# Altium schematic sheet schematic.SchDoc
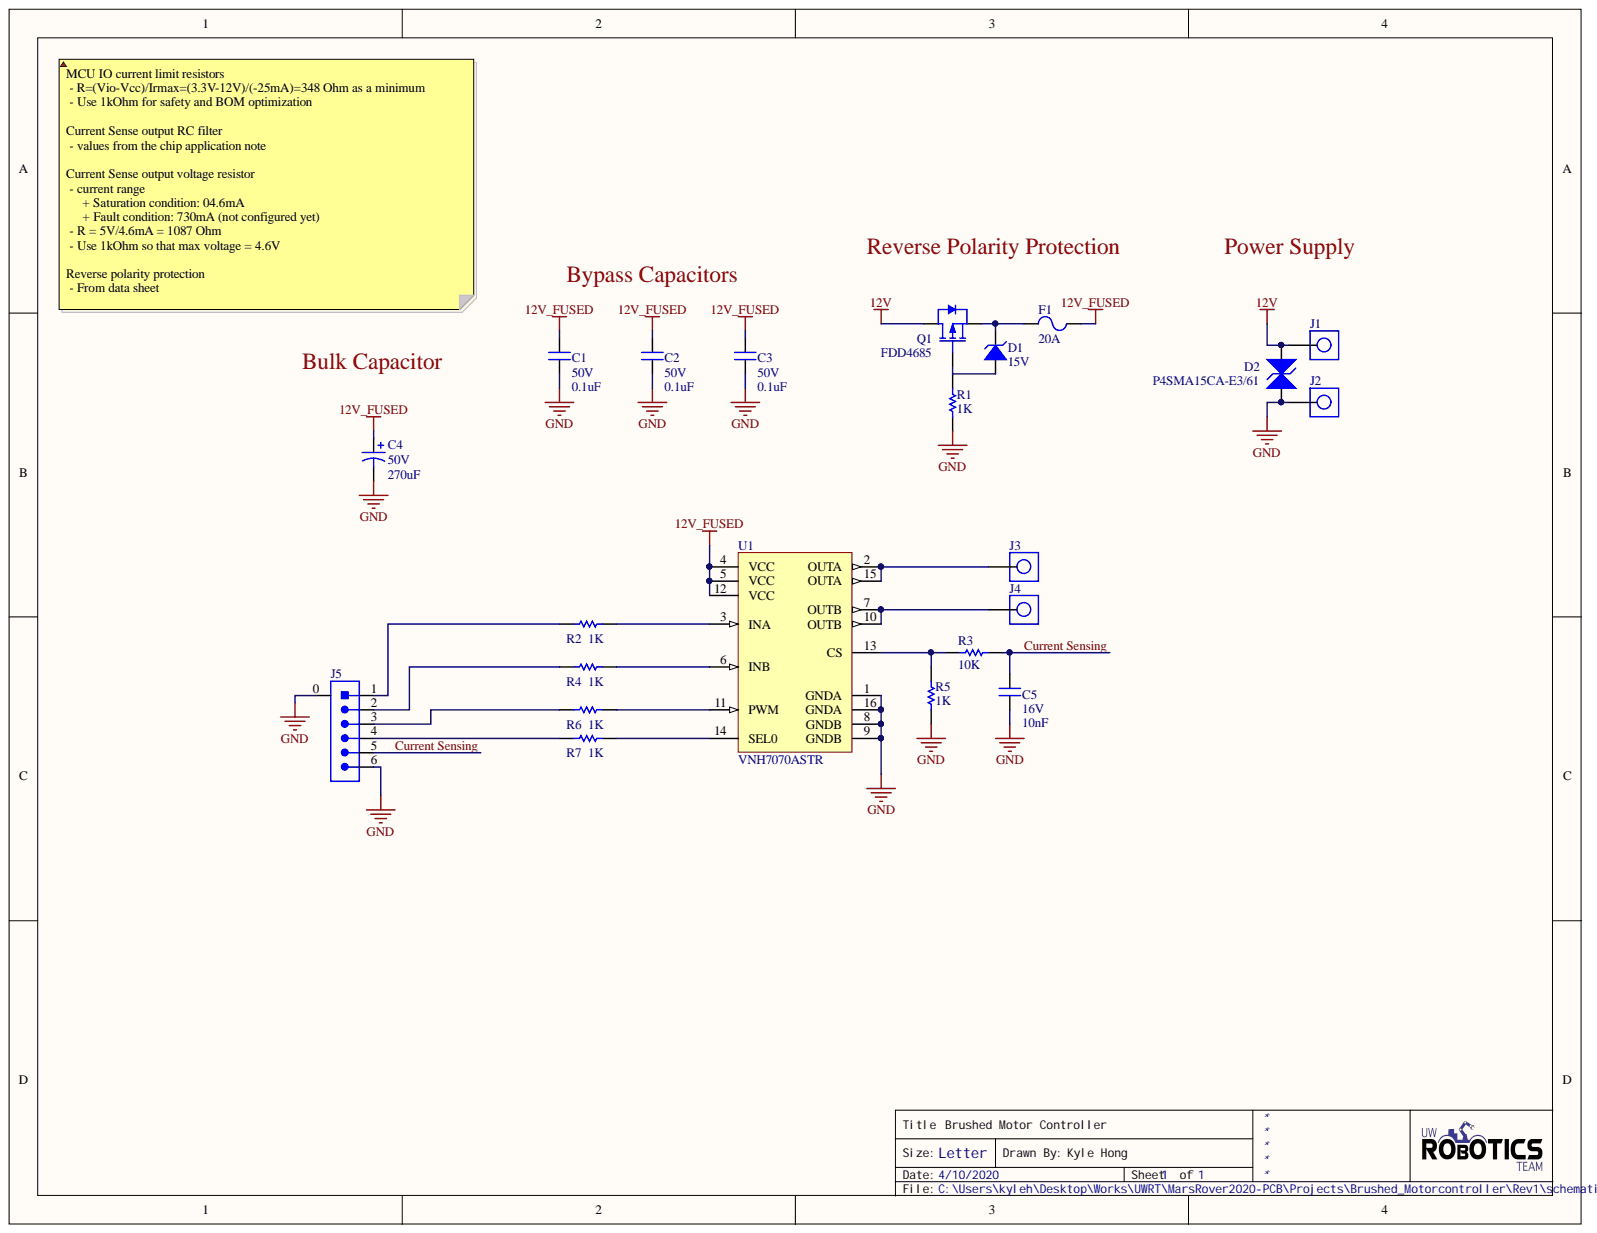
[diagram p0: schematic.SchDoc]

## Parts
C1  value 0.1uF  Voltage Rating: 50V  [CAPC0603(1608)55_N]  (hidden comment: CAP_0.1uF_50V_0603)
C2  value 0.1uF  Voltage Rating: 50V  [CAPC0603(1608)55_N]  (hidden comment: CAP_0.1uF_50V_0603)
C3  value 0.1uF  Voltage Rating: 50V  [CAPC0603(1608)55_N]  (hidden comment: CAP_0.1uF_50V_0603)
C4  Capacitance: 270uF  Voltage Rating: 50V  [EEE-FK1H271SP]  (hidden comment: CAP_270uF_50V_ALUM)
C5  value 10nF  Voltage Rating: 16V  [CAPC0603(1608)55_N]  (hidden comment: CAP_10nF_0603)
D1  Voltage Rating (DC): 15V  [SOD-323]  (hidden comment: DIO_ZENER_15V)
D2  Manufacturer Part Number 1: P4SMA15CA-E3/61  [TVS_DIODE_BIDIR_DO-214AC_SMA]  (hidden comment: TVS_DIODE_BIDIR_12.8V)
F1  Current Rating: 20A  [FUSE_1206]  (hidden comment: FUSE_20A_1206)
J1  Screw Terminal 6-32  [7701_KEYSTONE]  (value not drawn)
J2  Screw Terminal 6-32  [7701_KEYSTONE]  (value not drawn)
J3  Screw Terminal 6-32  [7701_KEYSTONE]  (value not drawn)
J4  Screw Terminal 6-32  [7701_KEYSTONE]  (value not drawn)
J5  CON_6M_SM_RA  [MOLEX-5024940670]  (value not drawn)
Q1  Manufacturer Part Number 1: FDD4685  [D-PAK]  (hidden comment: PMOS_40V_8.4A)
R1  value 1K  [RESC0603(1608)_N]  (hidden comment: RES_1K_0603)
R2  value 1K  [RESC0603(1608)_N]  (hidden comment: RES_1K_0603)
R3  value 10K  [RESC0603(1608)_N]  (hidden comment: RES_10K_0603)
R4  value 1K  [RESC0603(1608)_N]  (hidden comment: RES_1K_0603)
R5  value 1K  [RESC0603(1608)_N]  (hidden comment: RES_1K_0603)
R6  value 1K  [RESC0603(1608)_N]  (hidden comment: RES_1K_0603)
R7  value 1K  [RESC0603(1608)_N]  (hidden comment: RES_1K_0603)
U1  Interface: PWM  Manufacturer Part Number 1: VNH7070ASTR  [SO-16N]  (hidden comment: IC_MOTOR_DRIVER_ST)

## Nets
12V: D2.1 J1.1 Q1.1
12V_FUSED: C1.2 C2.2 C3.1 C4.1 F1.2 U1.4(VCC) U1.5(VCC) U1.12(VCC)
GND: C1.1 C2.1 C3.2 C4.2 C5.1 D2.2 J2.1 J5.0 J5.6 R5.1 U1.1(GNDA) U1.8(GNDB) U1.9(GNDB) U1.16(GNDA)
Current Sensing: C5.2 J5.5 R3.2
NetD1_1: D1.1 Q1.2
NetD1_2: D1.2 F1.1 Q1.3
NetJ3_1: J3.1 U1.2(OUTA) U1.15(OUTA)
NetJ4_1: J4.1 U1.7(OUTB) U1.10(OUTB)
NetJ5_1: J5.1 R2.1
NetJ5_2: J5.2 R4.1
NetJ5_3: J5.3 R6.1
NetJ5_4: J5.4 R7.1
NetR2_2: R2.2 U1.3(INA)
NetR3_1: R3.1 R5.2 U1.13(CS)
NetR4_2: R4.2 U1.6(INB)
NetR6_2: R6.2 U1.11(PWM)
NetR7_2: R7.2 U1.14(SEL0)

Not connected: R1 1 2

## Library data of the parts
C1-C3 = CAP_0.1uF_50V_0603: CAP CER 0.1UF 50V X7R 0603; Manufacturer 1: Yageo; Manufacturer Part Number 1: CC0603KRX7R9BB104; Component Kind: Standard; Dimensions: 0.063" L x 0.031" W (1.60mm x 0.80mm); Mount: Surface Mount; Package: 0603 (1608 Metric); Signal Integrity: Cap; Simulation: CAP; Supplier 1: Digi-Key; Supplier Part Number 1: 311-1344-1-ND; Temperature Coefficient: X7R; Library Name: UWRT_Schematic_Library_2020.SchLib
C4 = CAP_270uF_50V_ALUM: CAP ALUM 270UF 20% 50V RADIAL; Manufacturer 1: Panasonic; Manufacturer Part Number 1: EEEFK1H271SP; ESR (Equivalent Series Resistance): 180mR; Life (Hours): 2000Hour; Polarity: Polar; Ripple Current: 435.5mA; Ripple Current (AC): 670mA; Case/Package: Radial; Component Kind: Standard; ComponentLink1Description: https://media.digikey.com/pdf/Data%20Sheets/Panasonic%20Capacitors%20PDFs/FKS_Series_Type_V.pdf?src-supplier=Digi-Key; ComponentLink1URL: https://media.digikey.com/pdf/Data%20Sheets/Panasonic%20Capacitors%20PDFs/FKS_Series_Type_V.pdf?src-supplier=Digi-Key; ComponentLink2Description: https://media.digikey.com/pdf/Data%20Sheets/Panasonic%20Capacitors%20PDFs/FKS-V_Series_NPI.pdf?src-supplier=Digi-Key; ComponentLink2URL: https://media.digikey.com/pdf/Data%20Sheets/Panasonic%20Capacitors%20PDFs/FKS-V_Series_NPI.pdf?src-supplier=Digi-Key; ComponentLink3Description: http://www.farnell.com/datasheets/2813662.pdf?src-supplier=Farnell; ComponentLink3URL: http://www.farnell.com/datasheets/2813662.pdf?src-supplier=Farnell; ComponentLink4Description: http://www.farnell.com/datasheets/1996420.pdf?src-supplier=Element14; ComponentLink4URL: http://www.farnell.com/datasheets/1996420.pdf?src-supplier=Element14; ComponentLink5Description: https://industrial.panasonic.com/cdbs/www-data/pdf/RDE0000/DME0000COL90.pdf?src-supplier=Digi-Key; ComponentLink5URL: https://industrial.panasonic.com/cdbs/www-data/pdf/RDE0000/DME0000COL90.pdf?src-supplier=Digi-Key; Diameter: 10mm; Height: 10.2mm; Height - Seated (Max): 0.413inch; Max Operating Temperature: 105°C; Min Operating Temperature: -55°C; Mount: Surface Mount; Package Quantity: 500; Packaging: Cut Tape; Pricing 1: 1=0.98000, 10=0.74800, 100=0.53500 (USD); REACH SVHC: Unknown; RoHS: Yes; Stock 1: 730; Supplier 1: Digi-Key; Supplier Part Number 1: P18967CT-ND; Tolerance: 20%; Voltage Rating (DC): 50V; Library Name: UWRT_Schematic_Library_2020.SchLib
C5 = CAP_10nF_0603: CAP CER 10000PF 16V X7R 0603; Manufacturer 1: Yageo; Manufacturer Part Number 1: CC0603KRX7R7BB103; Component Kind: Standard; Dimensions: 0.063" L x 0.031" W (1.60mm x 0.80mm); Mount: Surface Mount; Package: 0603 (1608 Metric); Signal Integrity: Cap; Simulation: CAP; Supplier 1: Digi-Key; Supplier Part Number 1: 311-3369-1-ND; Temperature Coefficient: X7R; Library Name: UWRT_Schematic_Library_2020.SchLib
D1 = DIO_ZENER_15V: DIODE ZENER 15V 300mW SMD SOD-323; Manufacturer 1: ON Semiconductor; Manufacturer Part Number 1: MM3Z15VT1G; Breakdown Voltage: 15.8V; Element Configuration: Single; ESD Protection: Yes; Forward Voltage: 900mV; Impedance: 30R; Material: Plastic; Max Power Dissipation: 200mW; Max Reverse Leakage Current: 50nA; Test Current: 5mA; Tolerance: 5%; Zener Current: 10mA; Case/Package: SOD-323-2; Component Kind: Standard; ComponentLink1Description: https://datasheet.ciiva.com/16292/mm3z12vst1g-16292931.pdf?src-supplier=Future+Electronics; ComponentLink1URL: https://datasheet.ciiva.com/16292/mm3z12vst1g-16292931.pdf?src-supplier=Future+Electronics; ComponentLink2Description: https://datasheet.ciiva.com/30478/0900766b8127c8bd-30478408.pdf?src-supplier=RSComponents; ComponentLink2URL: https://datasheet.ciiva.com/30478/0900766b8127c8bd-30478408.pdf?src-supplier=RSComponents; ComponentLink3Description: https://datasheet.ciiva.com/22626/1878251-22626885.pdf?src-supplier=Element14; ComponentLink3URL: https://datasheet.ciiva.com/22626/1878251-22626885.pdf?src-supplier=Element14; ComponentLink4Description: https://datasheet.ciiva.com/894/mm3z2v4st1-d-894651.pdf?src-supplier=Digi-Key; ComponentLink4URL: https://datasheet.ciiva.com/894/mm3z2v4st1-d-894651.pdf?src-supplier=Digi-Key; ComponentLink5Description: https://datasheet.ciiva.com/894/mm3z2v4st1-d-894649.pdf?src-supplier=Digi-Key; ComponentLink5URL: https://datasheet.ciiva.com/894/mm3z2v4st1-d-894649.pdf?src-supplier=Digi-Key; ComponentLink6Description: https://datasheet.ciiva.com/25928/mm3z2v4st1-d-25928489.pdf?src-supplier=Avnet+Europe; ComponentLink6URL: https://datasheet.ciiva.com/25928/mm3z2v4st1-d-25928489.pdf?src-supplier=Avnet+Europe; ComponentLink7Description: https://datasheet.ciiva.com/894/mm3z2v4st1-d-894650.pdf?src-supplier=Digi-Key; ComponentLink7URL: https://datasheet.ciiva.com/894/mm3z2v4st1-d-894650.pdf?src-supplier=Digi-Key; ComponentLink8Description: https://datasheet.ciiva.com/18434/70100601-18434790.pdf?src-supplier=Allied+Electronics; ComponentLink8URL: https://datasheet.ciiva.com/18434/70100601-18434790.pdf?src-supplier=Allied+Electronics; ComponentLink9Description: https://datasheet.ciiva.com/8026/mm3z2v4t1-d-8026728.pdf?src-supplier=Element14; ComponentLink9URL: https://datasheet.ciiva.com/8026/mm3z2v4t1-d-8026728.pdf?src-supplier=Element14; ComponentLink10Description: https://datasheet.ciiva.com/22626/1876691-22626888.pdf?src-supplier=Element14; ComponentLink10URL: https://datasheet.ciiva.com/22626/1876691-22626888.pdf?src-supplier=Element14; ComponentLink11Description: https://datasheet.ciiva.com/894/mm3z2v4t1-d-894601.pdf?src-supplier=Digi-Key; ComponentLink11URL: https://datasheet.ciiva.com/894/mm3z2v4t1-d-894601.pdf?src-supplier=Digi-Key; ComponentLink12Description: https://datasheet.ciiva.com/10630/mm3z2v4t1-d-10630217.pdf?src-supplier=Avnet; ComponentLink12URL: https://datasheet.ciiva.com/10630/mm3z2v4t1-d-10630217.pdf?src-supplier=Avnet; ComponentLink13Description: https://datasheet.ciiva.com/894/mm3z2v4t1-d-894602.pdf?src-supplier=Digi-Key; ComponentLink13URL: https://datasheet.ciiva.com/894/mm3z2v4t1-d-894602.pdf?src-supplier=Digi-Key; ComponentLink14Description: https://datasheet.ciiva.com/894/mm3z2v4t1-d-894603.pdf?src-supplier=Digi-Key; ComponentLink14URL: https://datasheet.ciiva.com/894/mm3z2v4t1-d-894603.pdf?src-supplier=Digi-Key; Contact Plating: Tin,Matte; Height: 1mm; Lead Free: Lead Free; Length: 1.8mm; Max Operating Temperature: 150°C; Min Operating Temperature: -65°C; Number of Pins: 2; Package Quantity: 3000; Packaging: Tape and Reel; Peak Reverse Current: 50nA; Power Dissipation: 200mW; Power Rating: 200mW; Pricing 1: 1=0.14000, 10=0.13000, 25=0.12360, 100=0.05450, 250=0.05228, 500=0.04276, 1000=0.02970 (USD); Radiation Hardening: No; REACH SVHC: No SVHC; RoHS: Yes; Stock 1: 57470; Supplier 1: Digi-Key; Supplier Part Number 1: MM3Z15VT1GOSCT-ND; Voltage Tolerance: 5%; Width: 1.35mm; Working Voltage: 15V; Zener Voltage: 15V; Library Name: UWRT_Schematic_Library_2020.SchLib
D2 = TVS_DIODE_BIDIR_12.8V: TVS DIODE 12.8V 21.2V SMA; Manufacturer 1: Vishay Semiconductors; Breakdown Voltage: 15.8V; Direction: Bidirectional; Element Configuration: Single; Leakage Current: 1uA; Max Surge Current: 18.9A; Min Breakdown Voltage: 14.3V; Number of Bidirectional Channels: 1; Peak Pulse Power: 400W; Power Line Protection: No; Test Current: 1mA; Case/Package: SMA; Clamping Voltage: 21.2V; Component Kind: Standard; ComponentLink1Description: https://datasheet.ciiva.com/344/p4sma-344213.pdf?src-supplier=Digi-Key; ComponentLink1URL: https://datasheet.ciiva.com/344/p4sma-344213.pdf?src-supplier=Digi-Key; ComponentLink2Description: https://datasheet.ciiva.com/26212/p4sma-26212614.pdf?src-supplier=Avnet+Europe; ComponentLink2URL: https://datasheet.ciiva.com/26212/p4sma-26212614.pdf?src-supplier=Avnet+Europe; Composition: Zener; Max Operating Temperature: 150°C; Max Reverse Leakage Current: 1uA; Min Operating Temperature: -65°C; Mount: Surface Mount; Number of Channels: 1; Number of Elements: 1; Number of Pins: 2; Operating Supply Voltage: 12.8V; Package Quantity: 1800; Packaging: Tape and Reel; Peak Pulse Current: 18.9A; Polarity: Bidirectional; Pricing 1: 1=0.38000, 10=0.31000, 25=0.28400, 100=0.17820, 250=0.17624, 500=0.16500 (USD); Radiation Hardening: No; Reverse Breakdown Voltage: 14.3V; Reverse Standoff Voltage: 12.8V; RoHS: Yes; Stock 1: 5900; Supplier 1: Digi-Key; Supplier Part Number 1: P4SMA15CA-E3/61GICT-ND; Termination: SMD/SMT; Library Name: UWRT_Schematic_Library_2020.SchLib
F1 = FUSE_20A_1206: Manufacturer 1: Littelfuse; Manufacturer Part Number 1: 0501020.WR; Approvals: UL,CSA; Body Material: Ceramic; DC Cold Resistance: 2mR; Fuse Type: Board Mount (Cartridge Style Excluded); Power Dissipation: 1.1871W; Voltage Rating: 32V; Voltage Rating (DC): 24V; Case/Package: 1206; Case Code (Imperial): 1206; Case Code (Metric): 3216; Component Kind: Standard; ComponentLink1Description: https://datasheet.ciiva.com/3813/2da-3813990.pdf?src-supplier=Arrow; ComponentLink1URL: https://datasheet.ciiva.com/3813/2da-3813990.pdf?src-supplier=Arrow; ComponentLink2Description: https://datasheet.ciiva.com/1730/714013-1730635.pdf?src-supplier=Newark; ComponentLink2URL: https://datasheet.ciiva.com/1730/714013-1730635.pdf?src-supplier=Newark; ComponentLink3Description: https://datasheet.ciiva.com/46394/714013-46394876.pdf?src-supplier=Element14; ComponentLink3URL: https://datasheet.ciiva.com/46394/714013-46394876.pdf?src-supplier=Element14; ComponentLink4Description: https://datasheet.ciiva.com/299/littelfuse-fuse-501-series-299426.pdf?src-supplier=Digi-Key; ComponentLink4URL: https://datasheet.ciiva.com/299/littelfuse-fuse-501-series-299426.pdf?src-supplier=Digi-Key; ComponentLink5Description: https://datasheet.ciiva.com/299/littelfuse-fuse-501-series-299427.pdf?src-supplier=Digi-Key; ComponentLink5URL: https://datasheet.ciiva.com/299/littelfuse-fuse-501-series-299427.pdf?src-supplier=Digi-Key; ComponentLink6Description: https://datasheet.ciiva.com/299/littelfuse-fuse-501-series-299428.pdf?src-supplier=Digi-Key; ComponentLink6URL: https://datasheet.ciiva.com/299/littelfuse-fuse-501-series-299428.pdf?src-supplier=Digi-Key; Depth: 1.63mm; Fuse Current: 20A; Height: 0.033inch; Housing Material: Ceramic; Lead Free: Lead Free; Length: 3.2mm; Max Operating Temperature: 150°C; Min Operating Temperature: -55°C; Mount: Surface Mount; Package Quantity: 3000; Packaging: Tape and Reel; Pricing 1: 1=0.96000, 5=0.93000, 10=0.87100, 50=0.72080, 100=0.60060, 250=0.58560, 500=0.49550, 1000=0.43544 (USD); REACH SVHC: No SVHC; Resistance: 2mR; RoHS: Yes; Stock 1: 4551; Supplier 1: Digi-Key; Supplier Part Number 1: F2920CT-ND; Termination: SMD/SMT; Width: 0.064inch; Working Voltage: 24V; Library Name: UWRT_Schematic_Library_2020.SchLib
J1-J4 = SCREW_TERMINAL_1_POS_6_32: TERM W/ASSEMBLD SCREW VERT PCMNT; Manufacturer 1: Keystone Electronics; Manufacturer Part Number 1: 7701; Current Rating: 15A; Gender: Male; Insulation: Non-Insulated; Number of Contacts: 1; Color: Silver; Component Kind: Standard; ComponentLink1Description: https://datasheet.ciiva.com/13540/7701-289575-13540237.pdf?src-supplier=Mouser; ComponentLink1URL: https://datasheet.ciiva.com/13540/7701-289575-13540237.pdf?src-supplier=Mouser; ComponentLink2Description: https://datasheet.ciiva.com/16391/m60-2p62-16391562.pdf?src-supplier=Future+Electronics; ComponentLink2URL: https://datasheet.ciiva.com/16391/m60-2p62-16391562.pdf?src-supplier=Future+Electronics; ComponentLink3Description: https://datasheet.ciiva.com/14550/70181561-14550854.pdf?src-supplier=Allied+Electronics; ComponentLink3URL: https://datasheet.ciiva.com/14550/70181561-14550854.pdf?src-supplier=Allied+Electronics; Contact Material: Brass; Contact Plating: Tin,Nickel; Depth: 7.87mm; Diameter: 0.31inch; Height: 0.44inch; Lead Free: Lead Free; Length: 7.87mm; Material: Brass,Tin; Max Current Rating: 15A; Mount: Through Hole; Number of Pins: 4; Number of Ports: 1; Number of Terminals: 4; Orientation: Straight; Package Quantity: 100; Packaging: Box; Pricing 1: 1=0.57000, 25=0.47960, 100=0.40850, 250=0.38256, 500=0.35520, 1000=0.29820, 2500=0.26640 (USD); REACH SVHC: No SVHC; RoHS: Yes; Stock 1: 15600; Supplier 1: Digi-Key; Supplier Part Number 1: 36-7701-ND; Terminal Material: Brass; Termination: Solder; Library Name: UWRT_Schematic_Library_2020.SchLib
J5 = CON_6M_SM_RA: CONN HEADER SMD RIGHT ANGLE 2MM PITCH; Manufacturer 1: Molex; Manufacturer Part Number 1: 502494-0670; Connector Type: Receptacle,Forked; Current Rating: 3A; Gender: Receptacle; Glow Wire Compliant: Non-compliant; Insulation Resistance: 1GR; Max Voltage Rating (AC): 250V; Number of Circuits: 6; Color: Natural; Component Kind: Standard; ComponentLink1Description: https://datasheet.ciiva.com/755/5024940670-sd-755844.pdf?src-supplier=Digi-Key; ComponentLink1URL: https://datasheet.ciiva.com/755/5024940670-sd-755844.pdf?src-supplier=Digi-Key; ComponentLink2Description: https://datasheet.ciiva.com/24467/101355024940670-pcb-receptacles-24467588.pdf?src-supplier=Onlinecomponents.com; ComponentLink2URL: https://datasheet.ciiva.com/24467/101355024940670-pcb-receptacles-24467588.pdf?src-supplier=Onlinecomponents.com; ComponentLink3Description: https://datasheet.ciiva.com/16656/5024940670-pcb-receptacles-16656439.pdf?src-supplier=Avnet+Europe; ComponentLink3URL: https://datasheet.ciiva.com/16656/5024940670-pcb-receptacles-16656439.pdf?src-supplier=Avnet+Europe; ComponentLink4Description: https://datasheet.ciiva.com/7436/1630854-7436283.pdf?src-supplier=Newark; ComponentLink4URL: https://datasheet.ciiva.com/7436/1630854-7436283.pdf?src-supplier=Newark; ComponentLink5Description: https://datasheet.ciiva.com/5157/5024940670-pcb-receptacles-179322-5157180.pdf?src-supplier=Mouser; ComponentLink5URL: https://datasheet.ciiva.com/5157/5024940670-pcb-receptacles-179322-5157180.pdf?src-supplier=Mouser; ComponentLink6Description: https://datasheet.ciiva.com/7917/1630854-7917014.pdf?src-supplier=Element14; ComponentLink6URL: https://datasheet.ciiva.com/7917/1630854-7917014.pdf?src-supplier=Element14; ComponentLink7Description: https://datasheet.ciiva.com/30242/101355024940670-pcb-receptacles-30242550.pdf?src-supplier=Onlinecomponents.com; ComponentLink7URL: https://datasheet.ciiva.com/30242/101355024940670-pcb-receptacles-30242550.pdf?src-supplier=Onlinecomponents.com; ComponentLink8Description: https://datasheet.ciiva.com/755/5024940670-sd-755789.pdf?src-supplier=Digi-Key; ComponentLink8URL: https://datasheet.ciiva.com/755/5024940670-sd-755789.pdf?src-supplier=Digi-Key; Contact Gender: Female; Contact Material: Copper Alloy; Contact Plating: Tin; Depth: 6.85mm; Fastening Type: Latch; Flammability Rating: UL94 V-0; Housing Color: Natural; Housing Material: PBT; Length: 16mm; Material: Polybutylene; Max Operating Temperature: 85°C; Max Voltage Rating (DC): 250V; Min Operating Temperature: -25°C; Mount: Surface Mount; Number of Contacts: 6; Number of Positions: 6; Number of Rows: 1; Orientation: Right Angle; Package Quantity: 950; Packaging: Tape and Reel; Pitch: 2mm; Pricing 1: 1=0.81000, 10=0.70800, 25=0.66680, 50=0.63880, 100=0.61110, 250=0.55552 (USD); Radiation Hardening: No; REACH SVHC: Unknown; RoHS: Yes; Stock 1: 7093; Supplier 1: Digi-Key; Supplier Part Number 1: WM2283CT-ND; Termination: Solder; Voltage Rating: 250V; Library Name: UWRT_Schematic_Library_2020.SchLib
Q1 = PMOS_40V_8.4A: MOSFET P-CH 40V 8.4A D-PAK; Manufacturer 1: ON Semiconductor / Fairchild; Current Rating: -8.4A; Drain to Source Breakdown Voltage: -40V; Drain to Source Resistance: 27mR; Element Configuration: Single; Fall Time: 14ns; Gate to Source Voltage (Vgs): 20V; Input Capacitance: 2.38nF; Max Power Dissipation: 69W; Nominal Vgs: -1.6V; Number of Elements: 1; Rise Time: 15ns; Turn-Off Delay Time: 34ns; Turn-On Delay Time: 8ns; Case/Package: TO-252; Component Kind: Standard; ComponentLink1Description: https://datasheet.ciiva.com/6382/fdd4685-6382336.pdf?src-supplier=Digi-Key; ComponentLink1URL: https://datasheet.ciiva.com/6382/fdd4685-6382336.pdf?src-supplier=Digi-Key; ComponentLink2Description: https://datasheet.ciiva.com/22700/298848-22700881.pdf?src-supplier=Element14; ComponentLink2URL: https://datasheet.ciiva.com/22700/298848-22700881.pdf?src-supplier=Element14; ComponentLink3Description: https://datasheet.ciiva.com/4439/fdd4685-94891-4439978.pdf?src-supplier=Mouser; ComponentLink3URL: https://datasheet.ciiva.com/4439/fdd4685-94891-4439978.pdf?src-supplier=Mouser; ComponentLink4Description: https://datasheet.ciiva.com/7967/298848-7967879.pdf?src-supplier=Element14; ComponentLink4URL: https://datasheet.ciiva.com/7967/298848-7967879.pdf?src-supplier=Element14; ComponentLink5Description: https://datasheet.ciiva.com/26144/fdd4685-26144727.pdf?src-supplier=Avnet+Europe; ComponentLink5URL: https://datasheet.ciiva.com/26144/fdd4685-26144727.pdf?src-supplier=Avnet+Europe; ComponentLink6Description: https://datasheet.ciiva.com/22746/1930081-22746458.pdf?src-supplier=Element14; ComponentLink6URL: https://datasheet.ciiva.com/22746/1930081-22746458.pdf?src-supplier=Element14; ComponentLink7Description: https://datasheet.ciiva.com/22655/1930081-22655292.pdf?src-supplier=Element14; ComponentLink7URL: https://datasheet.ciiva.com/22655/1930081-22655292.pdf?src-supplier=Element14; ComponentLink8Description: https://datasheet.ciiva.com/16742/fdd4685-16742918.pdf?src-supplier=Future+Electronics; ComponentLink8URL: https://datasheet.ciiva.com/16742/fdd4685-16742918.pdf?src-supplier=Future+Electronics; ComponentLink9Description: https://datasheet.ciiva.com/11175/fdd4685-11175308.pdf?src-supplier=Avnet; ComponentLink9URL: https://datasheet.ciiva.com/11175/fdd4685-11175308.pdf?src-supplier=Avnet; Contact Plating: Tin,Matte; Continuous Drain Current (ID): 8.4A; Drain to Source Voltage (Vdss): 40V; Dual Supply Voltage: -40V; Height: 2.39mm; Lead Free: Lead Free; Length: 6.73mm; Max Operating Temperature: 150°C; Min Operating Temperature: -55°C; Mount: Surface Mount; Number of Pins: 3; On-State Resistance: 27MR; Package Quantity: 2500; Packaging: Tape and Reel; Power Dissipation: 69W; Pricing 1: 1=1.03000, 10=0.92200, 25=0.87560, 100=0.65670, 250=0.65040, 500=0.55660, 1000=0.45342 (USD); Radiation Hardening: No; Rds On Max: 27mR; REACH SVHC: No SVHC; RoHS: Yes; Stock 1: 9732; Supplier 1: Digi-Key; Supplier Part Number 1: FDD4685CT-ND; Termination: SMD/SMT; Threshold Voltage: -1.6V; Voltage Rating (DC): -40V; Weight: 0.26037g; Width: 6.22mm; Library Name: UWRT_Schematic_Library_2020.SchLib
R1 R2 R4-R7 = RES_1K_0603: RES SMD 1K OHM 5% 1/10W 0603; Manufacturer 1: Yageo; Manufacturer Part Number 1: RC0603JR-071KL; Component Kind: Standard; Dimensions: 0.063" L x 0.031" W (1.60mm x 0.80mm); Mount: Surface Mount; Package: 0603 (1608 Metric); Signal Integrity: Res; Simulation: RESISTOR; Supplier 1: Digi-Key; Supplier Part Number 1: 311-1.0KGRCT-ND; Tolerance: 5%; Library Name: UWRT_Schematic_Library_2020.SchLib
R3 = RES_10K_0603: RES SMD 10K OHM 5% 1/10W 0603; Manufacturer 1: Yageo; Manufacturer Part Number 1: RC0603JR-0710KL; Component Kind: Standard; Dimensions: 0.063" L x 0.031" W (1.60mm x 0.80mm); Mount: Surface Mount; Package: 0603 (1608 Metric); Signal Integrity: Res; Simulation: RESISTOR; Supplier 1: Digi-Key; Supplier Part Number 1: 311-10KGRCT-ND; Tolerance: 5%; Library Name: UWRT_Schematic_Library_2020.SchLib
U1 = IC_MOTOR_DRIVER_ST: IC H-BRIDGE MOTOR DRIVER 16-PINS STMicroelectronics VNH7070ASTR, SOIC; Logic Function: AND; Output Current: 15A; Case/Package: SOIC; Component Kind: Standard; ComponentLink1Description: http://www.farnell.com/datasheets/2367755.pdf?src-supplier=Farnell; ComponentLink1URL: http://www.farnell.com/datasheets/2367755.pdf?src-supplier=Farnell; ComponentLink2Description: http://www.mouser.com/ds/2/389/vnh7070as-957633.pdf?src-supplier=Mouser; ComponentLink2URL: http://www.mouser.com/ds/2/389/vnh7070as-957633.pdf?src-supplier=Mouser; ComponentLink3Description: http://www.st.com/content/ccc/resource/sales_and_marketing/promotional_material/flyer/5d/08/7a/88/37/7b/43/7d/flm07hbrid.pdf/files/flm07hbrid.pdf/jcr:content/translations/en.flm07hbrid.pdf?src-supplier=Digi-Key; ComponentLink3URL: http://www.st.com/content/ccc/resource/sales_and_marketing/promotional_material/flyer/5d/08/7a/88/37/7b/43/7d/flm07hbrid.pdf/files/flm07hbrid.pdf/jcr:content/translations/en.flm07hbrid.pdf?src-supplier=Digi-Key; Lead Free: Lead Free; Manufacturer 1: STMicroelectronics; Max Operating Temperature: 150°C; Min Operating Temperature: -40°C; Mount: Surface Mount; Number of Pins: 16; Packaging: Tape and Reel; Pricing 1: 1=3.49000, 10=3.13200, 25=2.96120, 100=2.43460, 250=2.18456, 500=2.10560, 1000=1.75028 (USD); RoHS: No; Stock 1: 2116; Supplier 1: Digi-Key; Supplier Part Number 1: 497-17630-1-ND; Library Name: UWRT_Schematic_Library_2020.SchLib
